# Altium schematic sheet PHOTODIODE.SchDoc
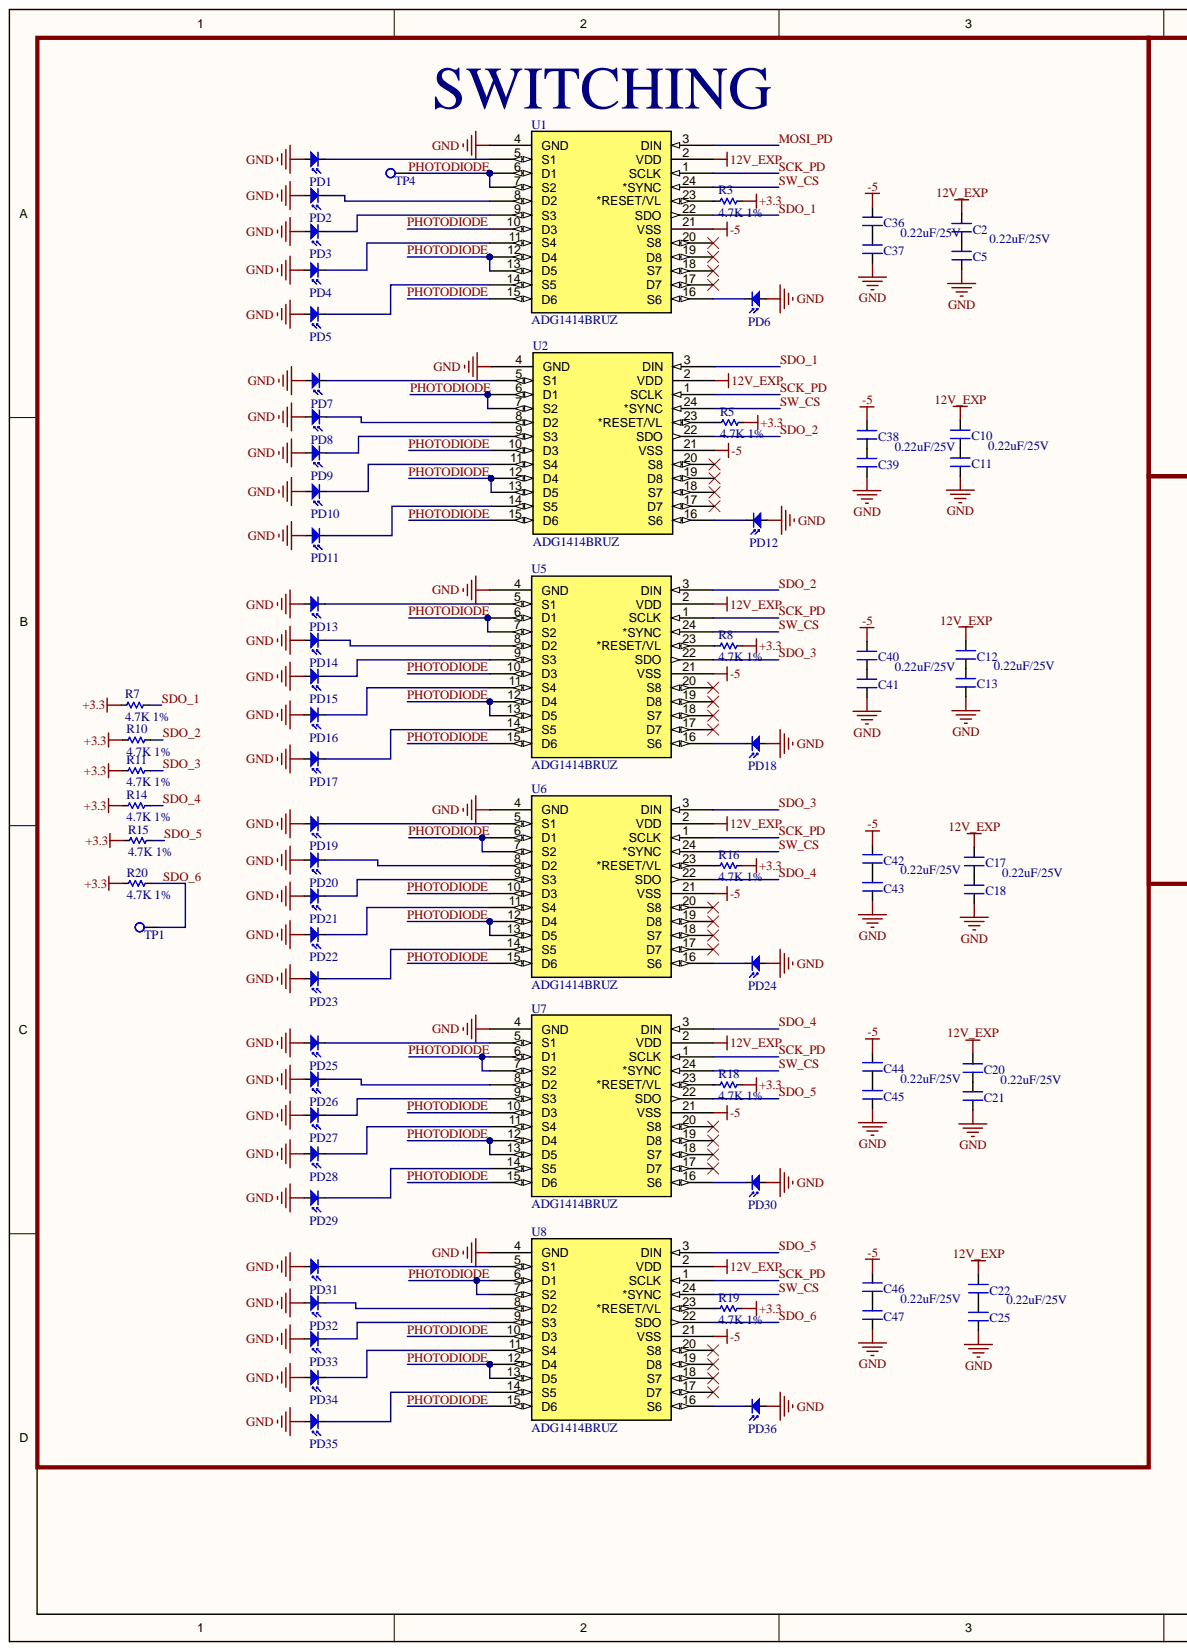
[diagram p0: PHOTODIODE.SchDoc, left]
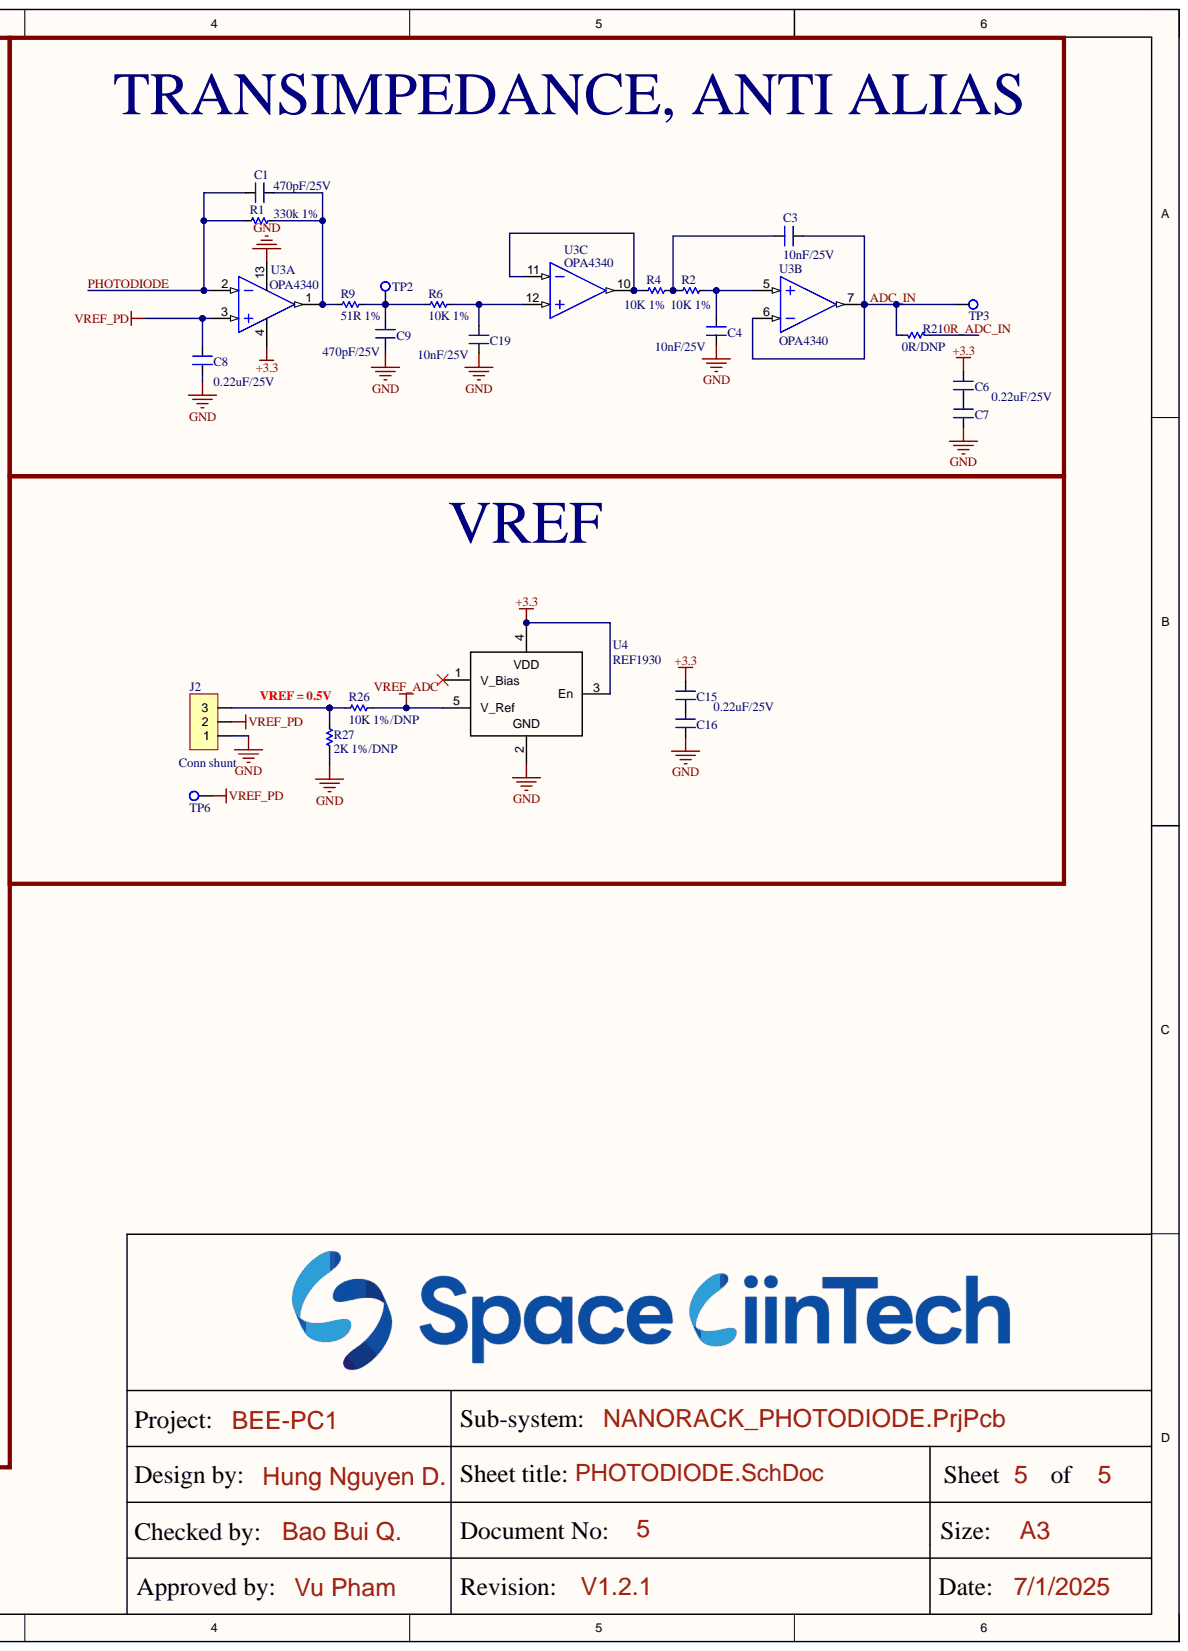
[diagram p1: PHOTODIODE.SchDoc, right]

## Parts
C1  470pF/25V  [CN-SMD-0603]
C2  0.22uF/25V  [CN-SMD-0603]
C3  10nF/25V  [CN-SMD-0603]
C4  10nF/25V  [CN-SMD-0603]
C5  0.22uF/25V  [CN-SMD-0603]
C6  0.22uF/25V  [CN-SMD-0603]
C7  0.22uF/25V  [CN-SMD-0603]
C8  0.22uF/25V  [CN-SMD-0603]
C9  470pF/25V  [CN-SMD-0603]
C10  0.22uF/25V  [CN-SMD-0603]
C11  0.22uF/25V  [CN-SMD-0603]
C12  0.22uF/25V  [CN-SMD-0603]
C13  0.22uF/25V  [CN-SMD-0603]
C15  0.22uF/25V  [CN-SMD-0603]
C16  0.22uF/25V  [CN-SMD-0603]
C17  0.22uF/25V  [CN-SMD-0603]
C18  0.22uF/25V  [CN-SMD-0603]
C19  10nF/25V  [CN-SMD-0603]
C20  0.22uF/25V  [CN-SMD-0603]
C21  0.22uF/25V  [CN-SMD-0603]
C22  0.22uF/25V  [CN-SMD-0603]
C25  0.22uF/25V  [CN-SMD-0603]
C36  0.22uF/25V  [CN-SMD-0603]
C37  0.22uF/25V  [CN-SMD-0603]
C38  0.22uF/25V  [CN-SMD-0603]
C39  0.22uF/25V  [CN-SMD-0603]
C40  0.22uF/25V  [CN-SMD-0603]
C41  0.22uF/25V  [CN-SMD-0603]
C42  0.22uF/25V  [CN-SMD-0603]
C43  0.22uF/25V  [CN-SMD-0603]
C44  0.22uF/25V  [CN-SMD-0603]
C45  0.22uF/25V  [CN-SMD-0603]
C46  0.22uF/25V  [CN-SMD-0603]
C47  0.22uF/25V  [CN-SMD-0603]
J2  Conn shunt  [M50-3930342]
PD1  Photodiode  [VEMD5080X01]  (value not drawn)
PD2  Photodiode  [VEMD5080X01]  (value not drawn)
PD3  Photodiode  [VEMD5080X01]  (value not drawn)
PD4  Photodiode  [VEMD5080X01]  (value not drawn)
PD5  Photodiode  [VEMD5080X01]  (value not drawn)
PD6  Photodiode  [VEMD5080X01]  (value not drawn)
PD7  Photodiode  [VEMD5080X01]  (value not drawn)
PD8  Photodiode  [VEMD5080X01]  (value not drawn)
PD9  Photodiode  [VEMD5080X01]  (value not drawn)
PD10  Photodiode  [VEMD5080X01]  (value not drawn)
PD11  Photodiode  [VEMD5080X01]  (value not drawn)
PD12  Photodiode  [VEMD5080X01]  (value not drawn)
PD13  Photodiode  [VEMD5080X01]  (value not drawn)
PD14  Photodiode  [VEMD5080X01]  (value not drawn)
PD15  Photodiode  [VEMD5080X01]  (value not drawn)
PD16  Photodiode  [VEMD5080X01]  (value not drawn)
PD17  Photodiode  [VEMD5080X01]  (value not drawn)
PD18  Photodiode  [VEMD5080X01]  (value not drawn)
PD19  Photodiode  [VEMD5080X01]  (value not drawn)
PD20  Photodiode  [VEMD5080X01]  (value not drawn)
PD21  Photodiode  [VEMD5080X01]  (value not drawn)
PD22  Photodiode  [VEMD5080X01]  (value not drawn)
PD23  Photodiode  [VEMD5080X01]  (value not drawn)
PD24  Photodiode  [VEMD5080X01]  (value not drawn)
PD25  Photodiode  [VEMD5080X01]  (value not drawn)
PD26  Photodiode  [VEMD5080X01]  (value not drawn)
PD27  Photodiode  [VEMD5080X01]  (value not drawn)
PD28  Photodiode  [VEMD5080X01]  (value not drawn)
PD29  Photodiode  [VEMD5080X01]  (value not drawn)
PD30  Photodiode  [VEMD5080X01]  (value not drawn)
PD31  Photodiode  [VEMD5080X01]  (value not drawn)
PD32  Photodiode  [VEMD5080X01]  (value not drawn)
PD33  Photodiode  [VEMD5080X01]  (value not drawn)
PD34  Photodiode  [VEMD5080X01]  (value not drawn)
PD35  Photodiode  [VEMD5080X01]  (value not drawn)
PD36  Photodiode  [VEMD5080X01]  (value not drawn)
R1  330k 1%  [RES-SMD-0603]
R2  10K 1%  [RES-SMD-0603]
R3  4.7K 1%  [RES-SMD-0603]
R4  10K 1%  [RES-SMD-0603]
R5  4.7K 1%  [RES-SMD-0603]
R6  10K 1%  [RES-SMD-0603]
R7  4.7K 1%  [RES-SMD-0603]
R8  4.7K 1%  [RES-SMD-0603]
R9  51R 1%  [RES-SMD-0603]
R10  4.7K 1%  [RES-SMD-0603]
R11  4.7K 1%  [RES-SMD-0603]
R14  4.7K 1%  [RES-SMD-0603]
R15  4.7K 1%  [RES-SMD-0603]
R16  4.7K 1%  [RES-SMD-0603]
R18  4.7K 1%  [RES-SMD-0603]
R19  4.7K 1%  [RES-SMD-0603]
R20  4.7K 1%  [RES-SMD-0603]
R21  0R/DNP  [RES-SMD-0603]
R26  10K 1%/DNP  [RES-SMD-0603]
R27  2K 1%/DNP  [RES-SMD-0603]
TP1  Test_Point  [TESTPOINT]  (value not drawn)
TP2  Test_Point  [TESTPOINT]  (value not drawn)
TP3  Test_Point  [TESTPOINT]  (value not drawn)
TP4  Test_Point  [TESTPOINT]  (value not drawn)
TP6  Test_Point  [TESTPOINT]  (value not drawn)
U1  ADG1414BRUZ  [TSSOP24]
U2  ADG1414BRUZ  [TSSOP24]
U3  OPA4340  [SSOP16]  (parts A,B,C)
U4  REF1930  [SOT23-5]
U5  ADG1414BRUZ  [TSSOP24]
U6  ADG1414BRUZ  [TSSOP24]
U7  ADG1414BRUZ  [TSSOP24]
U8  ADG1414BRUZ  [TSSOP24]

## Nets
12V_EXP: C2.2 C10.2 C12.2 C17.2 C20.2 C22.2 U1.2(VDD) U2.2(VDD) U5.2(VDD) U6.2(VDD) U7.2(VDD) U8.2(VDD)
+3.3: C6.2 C15.2 R3.2 R5.2 R7.2 R8.2 R10.2 R11.2 R14.2 R15.2 R16.2 R18.2 R19.2 R20.2 U3.4 U4.3(En) U4.4
-5: C36.2 C38.2 C40.2 C42.2 C44.2 C46.2 U1.21(VSS) U2.21(VSS) U5.21(VSS) U6.21(VSS) U7.21(VSS) U8.21(VSS)
GND: C4.1 C5.1 C7.1 C8.1 C9.1 C11.1 C13.1 C16.1 C18.1 C19.1 C21.1 C25.1 C37.1 C39.1 C41.1 C43.1 C45.1 C47.1 J2.1 PD1.1 PD2.1 PD3.1 PD4.1 PD5.1 PD6.1 PD7.1 PD8.1 PD9.1 PD10.1 PD11.1 PD12.1 PD13.1 PD14.1 PD15.1 PD16.1 PD17.1 PD18.1 PD19.1 PD20.1 PD21.1 PD22.1 PD23.1 PD24.1 PD25.1 PD26.1 PD27.1 PD28.1 PD29.1 PD30.1 PD31.1 PD32.1 PD33.1 PD34.1 PD35.1 PD36.1 R27.1 U1.4(GND) U2.4(GND) U3.13 U4.2 U5.4(GND) U6.4(GND) U7.4(GND) U8.4(GND)
VREF_ADC: R26.1 U4.5(V_Ref)
VREF_PD: C8.2 J2.2 TP6.1 U3.3
0R_ADC_IN: R21.1
ADC_IN: C3.1 R21.2 TP3.1 U3.6 U3.7
MOSI_PD: U1.3(DIN)
PHOTODIODE: C1.1 R1.1 TP4.1 U1.6(D1) U1.7(S2) U1.10(D3) U1.12(D4) U1.13(D5) U1.15(D6) U2.6(D1) U2.7(S2) U2.10(D3) U2.12(D4) U2.13(D5) U2.15(D6) U3.2 U5.6(D1) U5.7(S2) U5.10(D3) U5.12(D4) U5.13(D5) U5.15(D6) U6.6(D1) U6.7(S2) U6.10(D3) U6.12(D4) U6.13(D5) U6.15(D6) U7.6(D1) U7.7(S2) U7.10(D3) U7.12(D4) U7.13(D5) U7.15(D6) U8.6(D1) U8.7(S2) U8.10(D3) U8.12(D4) U8.13(D5) U8.15(D6)
SCK_PD: U1.1(SCLK) U2.1(SCLK) U5.1(SCLK) U6.1(SCLK) U7.1(SCLK) U8.1(SCLK)
SDO_1: R7.1 U1.22(SDO) U2.3(DIN)
SDO_2: R10.1 U2.22(SDO) U5.3(DIN)
SDO_3: R11.1 U5.22(SDO) U6.3(DIN)
SDO_4: R14.1 U6.22(SDO) U7.3(DIN)
SDO_5: R15.1 U7.22(SDO) U8.3(DIN)
SDO_6: R20.1 TP1.1 U8.22(SDO)
SW_CS: U1.24(*SYNC) U2.24(*SYNC) U5.24(*SYNC) U6.24(*SYNC) U7.24(*SYNC) U8.24(*SYNC)
NetC1_2: C1.2 R1.2 R9.1 U3.1
NetC2_1: C2.1 C5.2
NetC3_2: C3.2 R2.1 R4.2
NetC4_2: C4.2 R2.2 U3.5
NetC6_1: C6.1 C7.2
NetC9_2: C9.2 R6.1 R9.2 TP2.1
NetC10_1: C10.1 C11.2
NetC12_1: C12.1 C13.2
NetC15_1: C15.1 C16.2
NetC17_1: C17.1 C18.2
NetC19_2: C19.2 R6.2 U3.12
NetC20_1: C20.1 C21.2
NetC22_1: C22.1 C25.2
NetC36_1: C36.1 C37.2
NetC38_1: C38.1 C39.2
NetC40_1: C40.1 C41.2
NetC42_1: C42.1 C43.2
NetC44_1: C44.1 C45.2
NetC46_1: C46.1 C47.2
NetJ2_3: J2.3 R26.2 R27.2
NetPD1_2: PD1.2 U1.5(S1)
NetPD2_2: PD2.2 U1.8(D2)
NetPD3_2: PD3.2 U1.9(S3)
NetPD4_2: PD4.2 U1.11(S4)
NetPD5_2: PD5.2 U1.14(S5)
NetPD6_2: PD6.2 U1.16(S6)
NetPD7_2: PD7.2 U2.5(S1)
NetPD8_2: PD8.2 U2.8(D2)
NetPD9_2: PD9.2 U2.9(S3)
NetPD10_2: PD10.2 U2.11(S4)
NetPD11_2: PD11.2 U2.14(S5)
NetPD12_2: PD12.2 U2.16(S6)
NetPD13_2: PD13.2 U5.5(S1)
NetPD14_2: PD14.2 U5.8(D2)
NetPD15_2: PD15.2 U5.9(S3)
NetPD16_2: PD16.2 U5.11(S4)
NetPD17_2: PD17.2 U5.14(S5)
NetPD18_2: PD18.2 U5.16(S6)
NetPD19_2: PD19.2 U6.5(S1)
NetPD20_2: PD20.2 U6.8(D2)
NetPD21_2: PD21.2 U6.9(S3)
NetPD22_2: PD22.2 U6.11(S4)
NetPD23_2: PD23.2 U6.14(S5)
NetPD24_2: PD24.2 U6.16(S6)
NetPD25_2: PD25.2 U7.5(S1)
NetPD26_2: PD26.2 U7.8(D2)
NetPD27_2: PD27.2 U7.9(S3)
NetPD28_2: PD28.2 U7.11(S4)
NetPD29_2: PD29.2 U7.14(S5)
NetPD30_2: PD30.2 U7.16(S6)
NetPD31_2: PD31.2 U8.5(S1)
NetPD32_2: PD32.2 U8.8(D2)
NetPD33_2: PD33.2 U8.9(S3)
NetPD34_2: PD34.2 U8.11(S4)
NetPD35_2: PD35.2 U8.14(S5)
NetPD36_2: PD36.2 U8.16(S6)
NetR3_1: R3.1 U1.23(*RESET/VL)
NetR4_1: R4.1 U3.10 U3.11
NetR5_1: R5.1 U2.23(*RESET/VL)
NetR8_1: R8.1 U5.23(*RESET/VL)
NetR16_1: R16.1 U6.23(*RESET/VL)
NetR18_1: R18.1 U7.23(*RESET/VL)
NetR19_1: R19.1 U8.23(*RESET/VL)

Not connected: U1 17(D7) 18(S7) 19(D8) 20(S8); U2 17(D7) 18(S7) 19(D8) 20(S8); U4 1(V_Bias); U5 17(D7) 18(S7) 19(D8) 20(S8); U6 17(D7) 18(S7) 19(D8) 20(S8); U7 17(D7) 18(S7) 19(D8) 20(S8); U8 17(D7) 18(S7) 19(D8) 20(S8)

## Library data of the parts
C1-C13 C15-C22 C25 C36-C47 = CM: 0603; Value: 103; Component Kind: Standard; Library Name: Capacitors.SchLib
J2 = JP3: WR-PHD 2.54 mm Angled Socket Header; Manufacturer: W¨¹rth Elektronik eiSos GmbH; partNo: 613003143121; ComponentLink1 Description: Manufacturer; ComponentLink2 Description: Datasheet; NoPins: 03; Component Kind: Standard; ComponentLink1URL: http://www.we-online.com; ComponentLink2URL: http://katalog.we-online.de/em/datasheet/6130xx143121.pdf; Created Date: 29 March 2013; Library Name: Library.SchLib; Created by: Karrer Zheng
R1-R11 R14-R16 R18-R21 R26 R27 = Res-SMD: 0603; Manufacturer: Generic Components; Class: Passive; Component Kind: Standard; Library Name: Library.SchLib; Published: 08/06/2000; Publisher: Altium Hobart Technology Centre
U1 U2 U5-U8 = ADG1414BRUZ: IC SW SPST-NOX8 11.5OHM 24TSSOP; Component Kind: Standard; Library Name: Library_HUNG.SchLib
U3 = OPA4340: IC CMOS 4 CIRCUIT 16SSOP; Component Kind: Standard; Library Name: Library.SchLib; Supplier 1: Mouser; Supplier Part Number 1: 595-OPA4340UA/2K5
U4 = REF19xx: REF1941AIDDCT; Component Kind: Standard; Library Name: Library.SchLib
PD1-PD36 = Photodiode: Component Kind: Standard; Library Name: Library_HUNG.SchLib
TP1-TP4 TP6 = Test_Point: Component Kind: Standard; Library Name: Library_HUNG.SchLib
